# Altium schematic sheet p16_pinheader.SchDoc
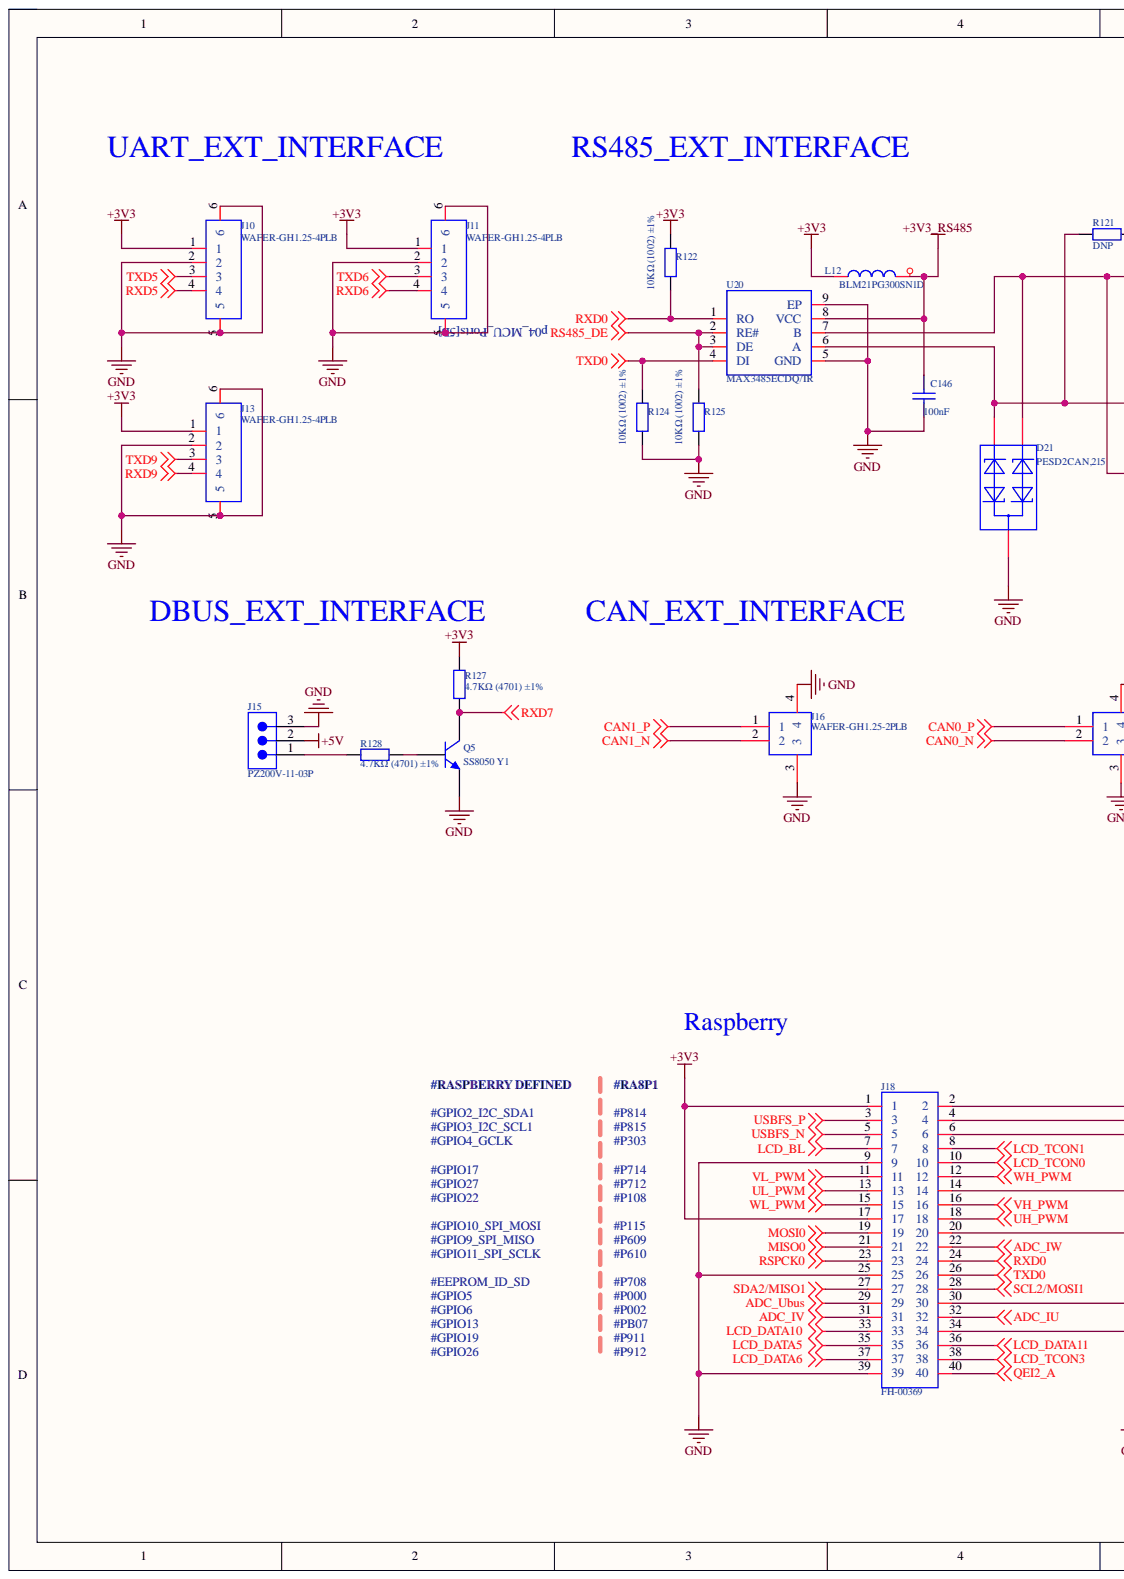
[diagram p0: p16_pinheader.SchDoc, left]
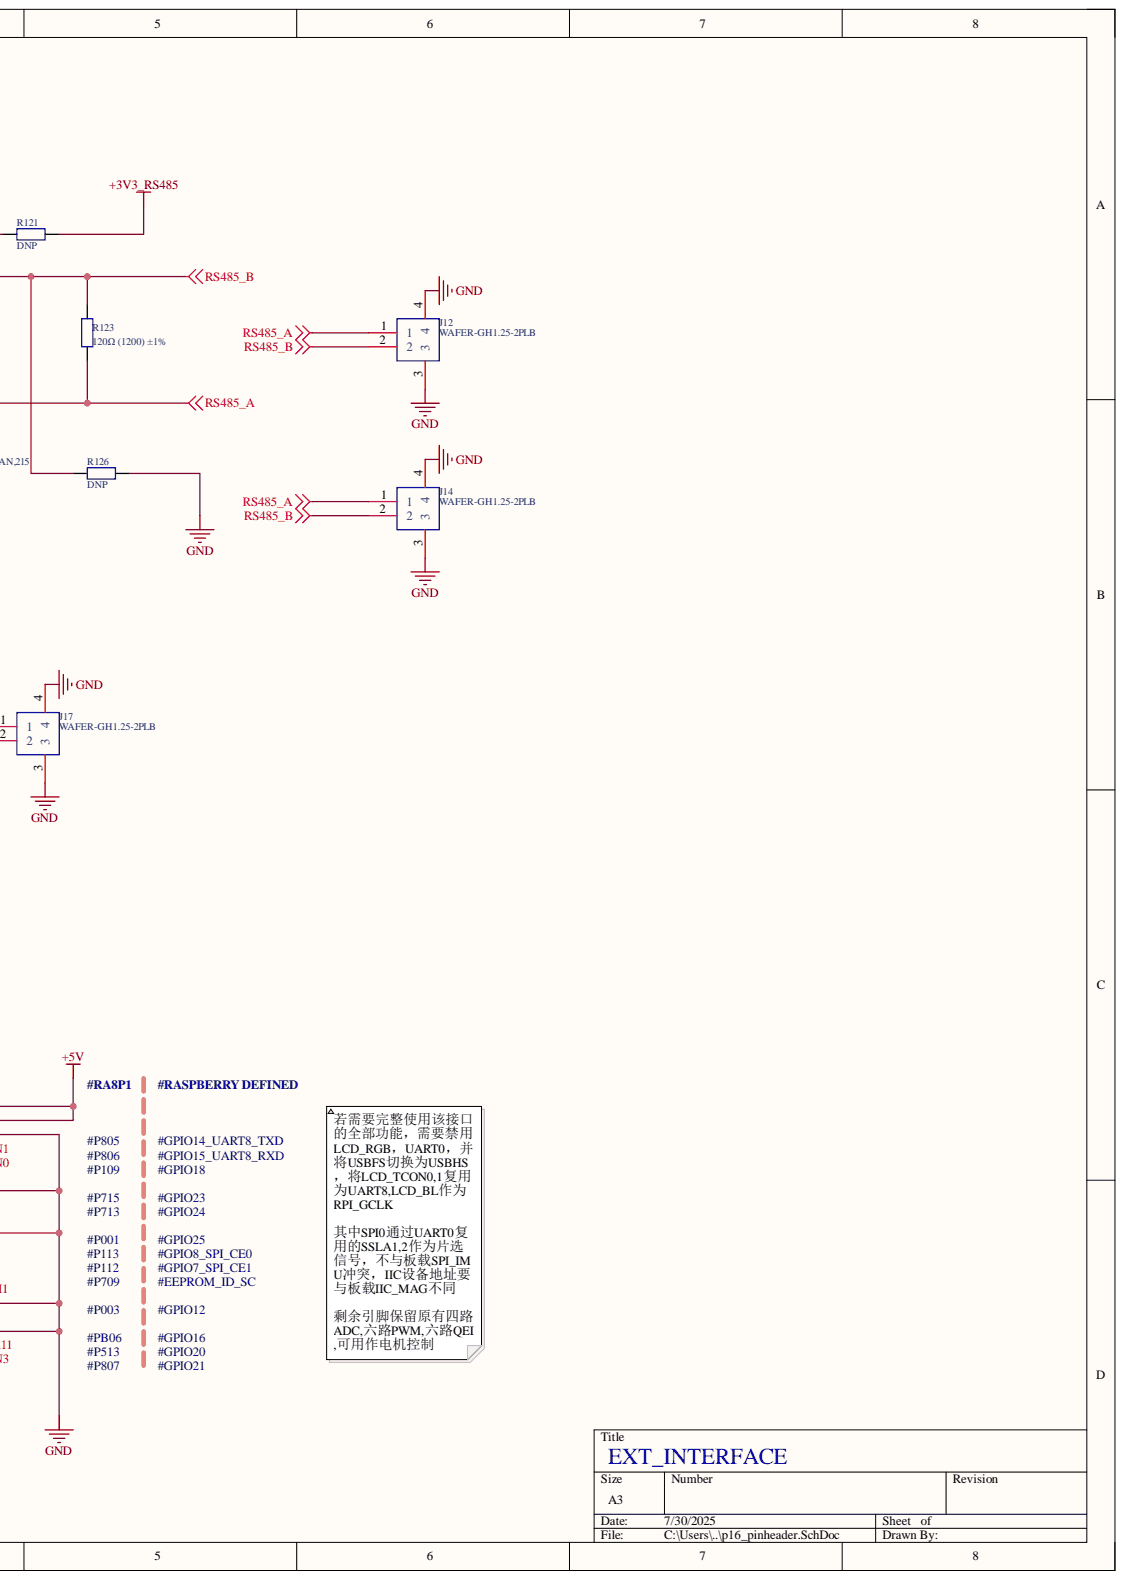
[diagram p1: p16_pinheader.SchDoc, right]

## Parts
C146  Capacitance: 100nF  [C0402]  (hidden comment: 100 nf (0.1uF) 16V)
D21  PESD2CAN,215  [SOT-23-3_L2.9-W1.3-P1.90-LS2.4-BR]
J10  WAFER-GH1.25-4PLB  [CONN-SMD_4P-P1.25_XUNPU_WAFER-GH1.25-4PLB]
J11  WAFER-GH1.25-4PLB  [CONN-SMD_4P-P1.25_XUNPU_WAFER-GH1.25-4PLB]
J12  WAFER-GH1.25-2PLB  [CONN-TH_2P-P1.25_XUNPU_WAFER-GH1.25-2PLB]
J13  WAFER-GH1.25-4PLB  [CONN-SMD_4P-P1.25_XUNPU_WAFER-GH1.25-4PLB]
J14  WAFER-GH1.25-2PLB  [CONN-TH_2P-P1.25_XUNPU_WAFER-GH1.25-2PLB]
J15  PZ200V-11-03P  [HDR-TH_3P-P2.00-V-M]
J16  WAFER-GH1.25-2PLB  [CONN-TH_2P-P1.25_XUNPU_WAFER-GH1.25-2PLB]
J17  WAFER-GH1.25-2PLB  [CONN-TH_2P-P1.25_XUNPU_WAFER-GH1.25-2PLB]
J18  FH-00369  [CONN-SMD_FH-00369]
L12  BLM21PG300SN1D  [L0805]
Q5  SS8050 Y1  [SOT-23(SOT-23-3)]
R121  DNP  [R0402]
R122  10KΩ (1002) ±1%  [R0402]
R123  120Ω (1200) ±1%  [R0402]
R124  10KΩ (1002) ±1%  [R0402]
R125  10KΩ (1002) ±1%  [R0402]
R126  DNP  [R0402]
R127  4.7KΩ (4701) ±1%  [R0402]
R128  4.7KΩ (4701) ±1%  [R0402]
U20  MAX3485ECDQ/TR  [DFN-8_L3.0-W3.0-P0.65-BL-EP-2]

## Nets
+3V3: J10.1 J11.1 J13.1 J18.1 J18.17 L12.1 R122.2 R127.2
+3V3_RS485: C146.2 L12.2 R121.1 U20.8(VCC)
+5V: J15.2 J18.2 J18.4
ADC_IU: J18.32
ADC_IV: J18.31
ADC_IW: J18.22
ADC_Ubus: J18.29
CAN0_N: J17.2
CAN0_P: J17.1
CAN1_N: J16.2
CAN1_P: J16.1
GND: C146.1 D21.3 J10.2 J10.5 J10.6 J11.2 J11.5 J11.6 J12.3 J12.4 J13.2 J13.5 J13.6 J14.3 J14.4 J15.3 J16.3 J16.4 J17.3 J17.4 J18.6 J18.9 J18.14 J18.20 J18.25 J18.30 J18.34 J18.39 Q5.2 R124.1 R125.1 R126.2 U20.5(GND) U20.9(EP)
LCD_BL: J18.7
LCD_DATA5: J18.35
LCD_DATA6: J18.37
LCD_DATA10: J18.33
LCD_DATA11: J18.36
LCD_TCON0: J18.10
LCD_TCON1: J18.8
LCD_TCON3: J18.38
MISO0: J18.21
MOSI0: J18.19
QEI2_A: J18.40
RS485_A: D21.2 J12.1 J14.1 R121.2 R123.2 U20.6(A)
RS485_B: D21.1 J12.2 J14.2 R123.1 R126.1 U20.7(B)
RS485_DE: R125.2 U20.2(RE#) U20.3(DE)
RSPCK0: J18.23
RXD0: J18.24 R122.1 U20.1(RO)
RXD5: J10.4
RXD6: J11.4
RXD7: Q5.3 R127.1
RXD9: J13.4
SCL2/MOSI1: J18.28
SDA2/MISO1: J18.27
TXD0: J18.26 R124.2 U20.4(DI)
TXD5: J10.3
TXD6: J11.3
TXD9: J13.3
UH_PWM: J18.18
UL_PWM: J18.13
USBFS_N: J18.5
USBFS_P: J18.3
VH_PWM: J18.16
VL_PWM: J18.11
WH_PWM: J18.12
WL_PWM: J18.15
NetJ15_1: J15.1 R128.1
NetQ5_1: Q5.1 R128.2

## Library data of the parts
C146 = CAP-000030-01: Ceramic Chip Capacitor 0402 100 nf (0.1uF) 16V; Legacy Part Number: 151-10004022; Manufacturer: Yageo; Manufacturer Part Number 1: CC0402KRX7R8BB104; Dialectric: X7R; Notes: ANY EQUIVALENT; Tolerance: 10%; Component Kind: Standard; Library Name: ra8p1_v0.1.SCHLIB; Manufacturer 1: Yageo; Manufacturer 2: Kemet; Manufacturer 3: AVX; Manufacturer Part Number 2: C0402C104K4RACTU; Manufacturer Part Number 3: 0402YC104KAT2A; Mfg: Yageo; Mfg P/N: CC0402KRX7R8BB104; Mfg Part Number: CC0402KRX7R8BB104; Package: 0402; PN: CC0402KRX7R8BB104; Supplier 1: Arrow Electronics; Supplier 2: Digi-Key; Supplier 3: Newark; Supplier 4: Mouser; Supplier Part Number 1: CC0402KRX7R8BB104; Supplier Part Number 2: 311-2077-1-ND; Supplier Part Number 3: 40AJ6370; Supplier Part Number 4: 603-CC402KRX7R8BB104; Voltage: 16V
D21 = PESD2CAN,215: Manufacturer: Nexperia(安世); Add into BOM: yes; Breakdown Voltage: 26.2V; Maximum Clamping Voltage: 41V; Number of Lines: 双路; Peak Pulse Current (Ipp)@10/1000us: 5A; Peak Pulse Power Dissipation (Ppp)@10/1000us: 230W; polarity: 双向; Reverse Stand-Off Voltage (Vrwm): 24V; Type: ESD; Component Kind: Standard; Convert to PCB: yes; Datasheet: https://atta.szlcsc.com/upload/public/pdf/source/20170505/1493951386298.pdf; Device: PESD2CAN,215; JLCPCB Part Class: Extended Part; LCSC Part Name: 双向ESD; Library Name: ra8p1_v0.1.SCHLIB; Manufacturer Part: PESD2CAN,215; Operating Temperature: -55℃~+150℃@(Ta); PCB3D: SOT-23-3P_L2.9-W1.3-H1.0-LS2.4-P0.95; Supplier: LCSC; Supplier Footprint: SOT-23; Supplier Part: C75176; Symbol: PESD2CAN,215
J10 J11 J13 = WAFER-GH1.25-4PLB: 立式; Manufacturer: XUNPU(讯普); Add into BOM: yes; Current Rating: 1A; Number of PINs Per Row: 4; Pins Structure: 1x4P; Pitch: 1.25mm; Plastic Material: LCP; Reference Series: GH; Supplementary Features: 辅助焊脚; Voltage Rating: 125V; X-Length of Bottom Edge on Board (Spacing Line): 8.25mm; Y-Width of Bottom Edge on Board: 4.95mm; Z-Height of the Board: 4.2mm; Color: 米色; Component Kind: Standard; Contact Material: 黄铜; Contact Plating: -; Convert to PCB: yes; Datasheet: https://atta.szlcsc.com/upload/public/pdf/source/20220527/44F824AAC8C2B617205E15955FF9C23D.pdf; Device: WAFER-GH1.25-4PLB; Flame Retardant Rating: -; JLCPCB Part Class: Extended Part; LCSC Part Name: 1x4P 间距:1.25mm 立贴 系列:GH; Library Name: ra8p1_v0.2.SCHLIB; Manufacturer Part: WAFER-GH1.25-4PLB; Mounting Type: 立贴; Number of Pins: 4P; Number of Rows: 1; Operating Temperature: -25℃~+85℃; PCB3D: CONN-TH_4P-P1.25_XUNPU_WAFER-GH1.25-4PLB; Row Spacing: -; Supplier: LCSC; Supplier Footprint: SMD,P=1.25mm; Supplier Part: C3029370; Symbol: WAFER-GH1.25-4PLB
J12 J14 J16 J17 = WAFER-GH1.25-2PLB: 立式; Manufacturer: XUNPU(讯普); Add into BOM: yes; Current Rating: 1A; Number of PINs Per Row: 2; Pins Structure: 1x2P; Pitch: 1.25mm; Plastic Material: LCP; Reference Series: GH; Supplementary Features: 辅助焊脚; Voltage Rating: 125V; X-Length of Bottom Edge on Board (Spacing Line): 5.75mm; Y-Width of Bottom Edge on Board: 4.95mm; Z-Height of the Board: 4.2mm; Color: 米色; Component Kind: Standard; Contact Material: 黄铜; Contact Plating: -; Convert to PCB: yes; Datasheet: https://atta.szlcsc.com/upload/public/pdf/source/20220527/44F824AAC8C2B617205E15955FF9C23D.pdf; Device: WAFER-GH1.25-2PLB; Flame Retardant Rating: -; JLCPCB Part Class: Extended Part; LCSC Part Name: 1x2P 间距:1.25mm 立贴 系列:GH; Library Name: ra8p1_v0.1.SCHLIB; Manufacturer Part: WAFER-GH1.25-2PLB; Mounting Type: 立贴; Number of Pins: 2P; Number of Rows: 1; Operating Temperature: -25℃~+85℃; PCB3D: CONN-TH_2P-P1.25_XUNPU_WAFER-GH1.25-2PLB; Row Spacing: -; Supplier: LCSC; Supplier Footprint: SMD,P=1.25mm; Supplier Part: C3029368; Symbol: WAFER-GH1.25-2PLB
J15 = CON-100009-01: Sullins Header 2mm pitch 3pos 1x3, vert, Au flash; Legacy Part Number: 160-10007400; Manufacturer Part Number 1: NRPN031PAEN-RC; Notes: ANY EQUIVALENT; Positions: 3; ClassName: JLOB; Component Kind: Standard; Library Name: Connectors.IntLib; Manufacturer: Sullins; Manufacturer 1: Sullins; Mfg: Sullins; Mfg P/N: NRPN031PAEN-RC; Mfg Part Number: NRPN031PAEN-RC; Pitch: 2mm; PN: NRPN031PAEN-RC; Supplier 1: Digi-Key; Supplier Part Number 1: S5800-03-ND
J18 = FH-00369: 穿孔排母贴片式，2.54，40p; Manufacturer: Liansheng(连盛); Add into BOM: yes; Circular Hole / Square Hole: 方孔; Current Rating (Max): 3A; Holes Direction: 顶部; Holes Structure: 2x20P; Number of Holes: 40P; Pitch: 2.54mm; Component Kind: Standard; Convert to PCB: yes; Datasheet: https://atta.szlcsc.com/upload/public/pdf/source/20210125/C2685115_8DB326D301B46C37D0E563AEC5552FFB.pdf; Device: FH-00369; Insulation Height: 3.9mm; JLCPCB Part Class: Extended Part; LCSC Part Name: 间距:2.54mm 2x20P 立贴; Library Name: ra8p1_v0.2.SCHLIB; Manufacturer Part: FH-00369; Mounting Type: 立贴; Number of Rows: 双排; Operating Temperature Range: -40℃~+105℃; PCB3D: CONN-SMD_FH-00369; Row Spacing: 2.54mm; Supplier: LCSC; Supplier Footprint: SMD,P=2.54mm; Supplier Part: C2685115; Symbol: FH-00369; Voltage Rating (Max): -
L12 = BLM21PG300SN1D: 磁珠，0805，4A; Manufacturer: muRata(村田); Add into BOM: yes; Circuits: 1; Current Rating: 2A; DC Resistance: 45mΩ; Device: BLM21PG221SN1D; Impedance @ Frequency: 220Ω@100MHz; Tolerance: ±25%; Component Kind: Standard; Convert to PCB: yes; Datasheet: https://atta.szlcsc.com/upload/public/pdf/source/20180326/C118443_3FAC30E9A4F0E6B81275543C61E0685E.pdf; JLCPCB Part Class: Extended Part; LCSC Part Name: 单路 0805磁珠 阻抗220Ω@100MHz; Library Name: ra8p1_v0.1.SCHLIB; Manufacturer Part: BLM21PG221SN1D; PCB3D: R0805_L2.0-W1.3-H0.6; Supplier: LCSC; Supplier Footprint: 0805; Supplier Part: C85840; Symbol: BLM21PG221SN1D
Q5 = SOT-23 SS8050 Y1: 三极管; Component Kind: Standard; ComponentLink1Description: 供应商链接; ComponentLink1URL: http://www.szlcsc.com/product/details_2507.html; Library Name: Transistor_NPN.SchLib; Package: SOT-23; Supplier: LC; SuppliersPartNumber: C2150
R121 R126 = 0402 4.7KΩ (4701) 1%: 贴片电阻,4.7K; Component Kind: Standard; ComponentLink1Description: 供应商链接; ComponentLink1URL: http://www.szlcsc.com/product/details_26643.html; Library Name: RES.SchLib; Package: 0402; Supplier: LC; SuppliersPartNumber: C25900
R122 R124 R125 = 0402 10KΩ (1002) 1%: 贴片电阻，10K; Component Kind: Standard; ComponentLink1Description: 供应商链接; ComponentLink1URL: http://www.szlcsc.com/product/details_26487.html; Library Name: RES.SchLib; Package: 0402; Supplier: LC; SuppliersPartNumber: C25744
R123 = 0402 120Ω (1200) 1%: 贴片电阻; Component Kind: Standard; ComponentLink1Description: 供应商链接; ComponentLink1URL: http://www.szlcsc.com/product/details_25822.html; Library Name: RES.SchLib; Package: 0402; Supplier: LC; SuppliersPartNumber: C25079
R127 R128 = 0402 4.7KΩ (4701) 1%: 贴片电阻; Component Kind: Standard; ComponentLink1Description: 供应商链接; ComponentLink1URL: http://www.szlcsc.com/product/details_26643.html; Library Name: RES.SchLib; Package: 0402; Supplier: LC; SuppliersPartNumber: C25900
U20 = MAX3485ECDQ/TR: RS485，DFN-8; Manufacturer: HGSEMI(华冠); Add into BOM: yes; Component Kind: Standard; Convert to PCB: yes; Datasheet: https://atta.szlcsc.com/upload/public/pdf/source/20250110/B4AF586D1EC3BAA870D633A70EBF96E7.pdf; Device: MAX3485ECDQ/TR; JLCPCB Part Class: Extended Part; LCSC Part Name: MAX3485ECDQ/TR; Library Name: ra8p1_v0.1.SCHLIB; Manufacturer Part: MAX3485ECDQ/TR; PCB3D: DFN-8_L3.0-W3.0-P0.65-BL-EP-2; Supplier: LCSC; Supplier Footprint: DFN-8-EP(3x3); Supplier Part: C42441904; Symbol: MAX3485ECDQ/TR
